# Altium schematic sheet Power_Supply.SchDoc(Power_Supply)
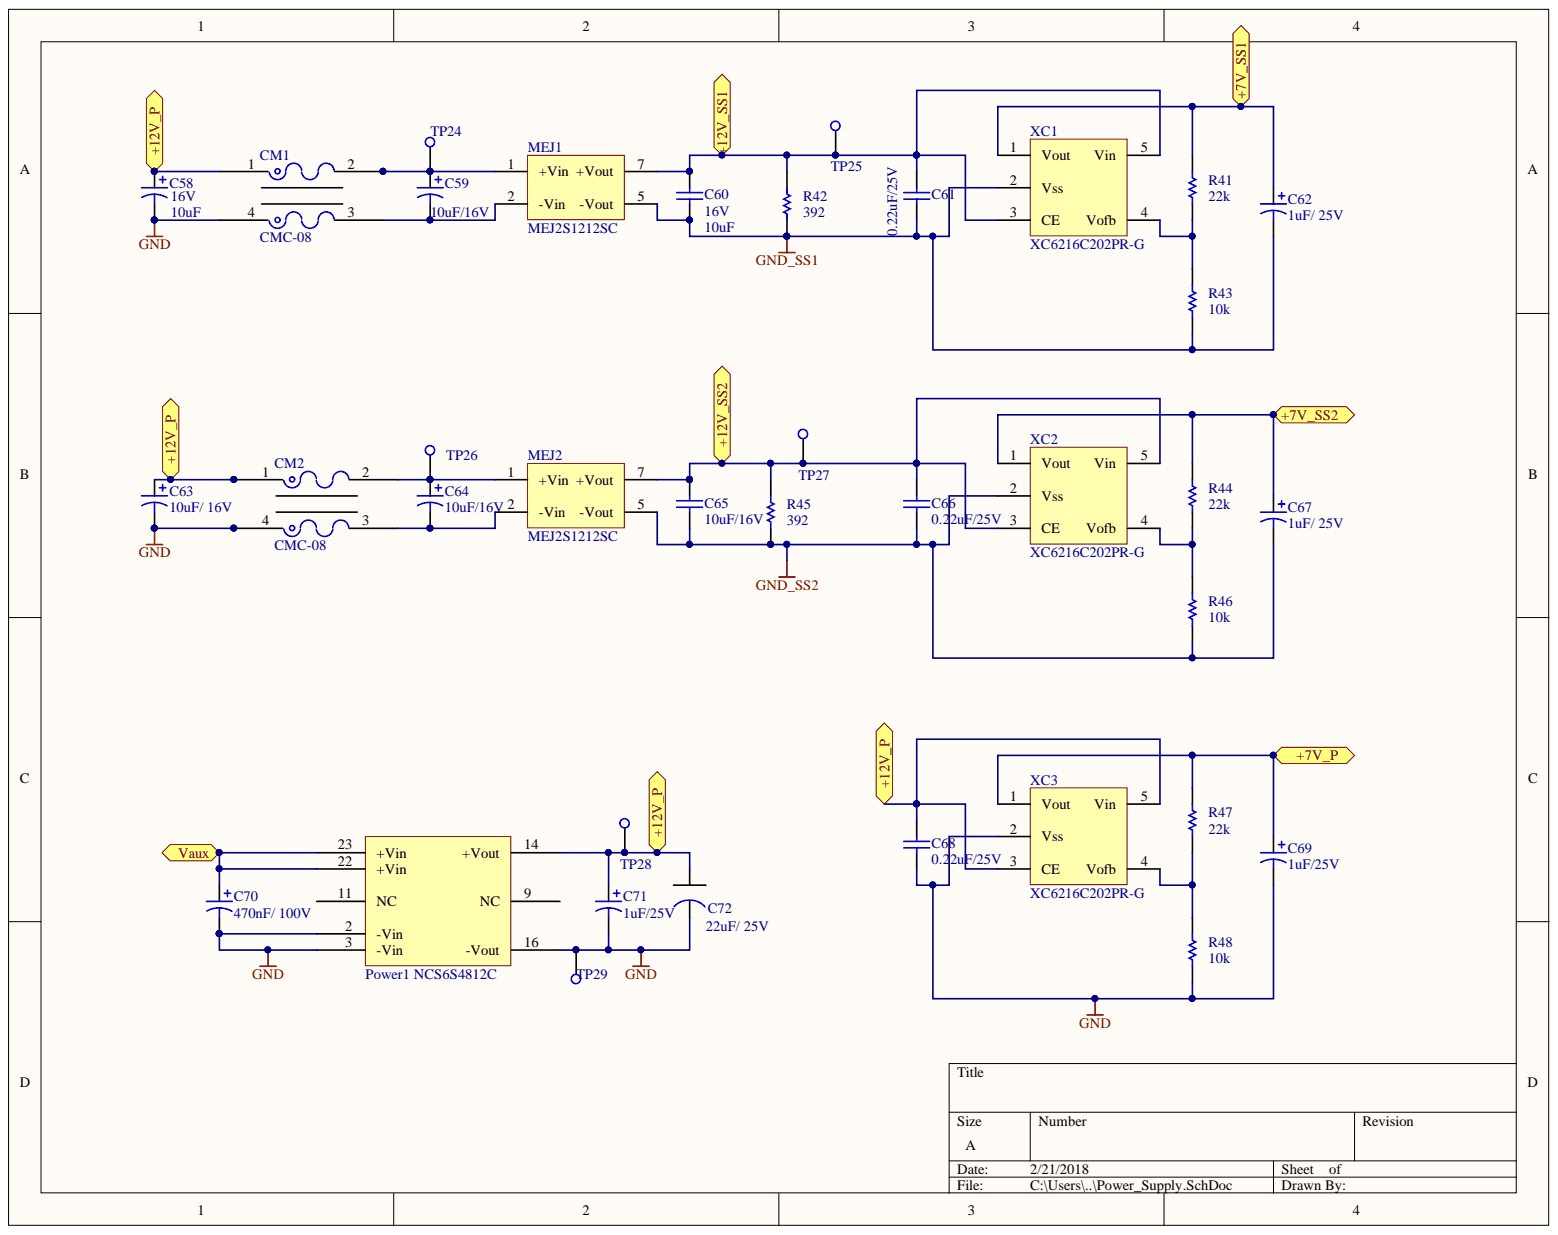
[diagram p0: Power_Supply.SchDoc]

## Parts
C58  16V  value 10uF  [1812]
C59  value 10uF/16V  [1812]  (hidden comment: Cap Pol3)
C60  16V  value 10uF  [C1206]
C61  value 0.22uF/25V  [C1206]  (hidden comment: Cap Semi)
C62  value 1uF/ 25V  [C0805]  (hidden comment: Cap Pol3)
C63  value 10uF/ 16V  [1812]  (hidden comment: Cap Pol3)
C64  value 10uF/16V  [1812]  (hidden comment: Cap Pol3)
C65  value 10uF/16V  [C1206]  (hidden comment: Cap Semi)
C66  value 0.22uF/25V  [C1206]  (hidden comment: Cap Semi)
C67  value 1uF/ 25V  [C0805]  (hidden comment: Cap Pol3)
C68  value 0.22uF/25V  [C1206]  (hidden comment: Cap Semi)
C69  value 1uF/25V  [C0805]  (hidden comment: Cap Pol3)
C70  value 470nF/ 100V  [C0805]  (hidden comment: Cap Pol3)
C71  value 1uF/25V  [C0805]  (hidden comment: Cap Pol3)
C72  22uF  [Cap_tantalum]
CM1  CMC-08  [CMC-08]
CM2  CMC-08  [CMC-08]
MEJ1  MEJ2S1212SC  [MEJ2]
MEJ2  MEJ2S1212SC  [MEJ2]
Power1  NCS6S4812C  [Power_main]
R41  res_smd  [res_smd]  (value not drawn)
R42  res_smd  [res_smd]  (value not drawn)
R43  res_smd  [res_smd]  (value not drawn)
R44  res_smd  [res_smd]  (value not drawn)
R45  res_smd  [res_smd]  (value not drawn)
R46  res_smd  [res_smd]  (value not drawn)
R47  res_smd  [res_smd]  (value not drawn)
R48  res_smd  [res_smd]  (value not drawn)
TP24  Test Point  [Test Point]  (value not drawn)
TP25  Test Point  [Test Point]  (value not drawn)
TP26  Test Point  [Test Point]  (value not drawn)
TP27  Test Point  [Test Point]  (value not drawn)
TP28  Test Point  [Test Point]  (value not drawn)
TP29  Test Point  [Test Point]  (value not drawn)
XC1  XC6216C202PR-G  [SOT-89-5]
XC2  XC6216C202PR-G  [SOT-89-5]
XC3  XC6216C202PR-G  [SOT-89-5]

## Ports
+7V_P, +7V_SS1, +7V_SS2, +12V_P, +12V_SS1, +12V_SS2, Vaux

## Nets
GND: C58.2 C63.2 C68.1 C69.2 C70.2 C71.2 C72.2 CM1.4 CM2.4 Power1.2(-Vin) Power1.3(-Vin) Power1.16(-Vout) R48.2 TP29.1 XC3.2(Vss)
GND_SS1: C60.1 C61.1 C62.2 MEJ1.5(-Vout) R42.2 R43.2 XC1.2(Vss)
GND_SS2: C65.1 C66.1 C67.2 MEJ2.5(-Vout) R45.2 R46.2 XC2.2(Vss)
+7V_P: C69.1 R47.1 XC3.1(Vout) ; port +7V_P
+7V_SS1: C62.1 R41.1 XC1.1(Vout) ; port +7V_SS1
+7V_SS2: C67.1 R44.1 XC2.1(Vout) ; port +7V_SS2
+12V_P: C58.1 C63.1 C68.2 C71.1 C72.1 CM1.1 CM2.1 Power1.14(+Vout) TP28.1 XC3.3(CE) XC3.5(Vin) ; port +12V_P
+12V_SS1: C60.2 C61.2 MEJ1.7(+Vout) R42.1 TP25.1 XC1.3(CE) XC1.5(Vin) ; port +12V_SS1
+12V_SS2: C65.2 C66.2 MEJ2.7(+Vout) R45.1 TP27.1 XC2.3(CE) XC2.5(Vin) ; port +12V_SS2
Vaux: C70.1 Power1.22(+Vin) Power1.23(+Vin) ; port Vaux
NetC59_1: C59.1 CM1.2 MEJ1.1(+Vin) TP24.1
NetC59_2: C59.2 CM1.3 MEJ1.2(-Vin)
NetC64_1: C64.1 CM2.2 MEJ2.1(+Vin) TP26.1
NetC64_2: C64.2 CM2.3 MEJ2.2(-Vin)
NetR41_2: R41.2 R43.1 XC1.4(Vofb)
NetR44_2: R44.2 R46.1 XC2.4(Vofb)
NetR47_2: R47.2 R48.1 XC3.4(Vofb)

Not connected: Power1 9(NC) 11(NC)

## Library data of the parts
C58 C59 C62-C64 C67 C69 C71 = Cap Pol3: Polarized Capacitor (Surface Mount); Simulation: CAP; Signal Integrity: Cap Pol; Published: 8-Jun-2000; PackageReference: C0805; PackageDescription: Chip Capacitor; Library Name: Miscellaneous Devices.SchLib; LatestRevisionNote: IPC-7351 Footprint Added.; LatestRevisionDate: 29-May-2009; Component Kind: Standard; Publisher: Altium Limited
C60 C61 C65 C66 C68 = Cap Semi: Capacitor (Semiconductor SIM Model); Simulation: CAP; Signal Integrity: Cap Semi; Published: 8-Jun-2000; PackageReference: C1206; PackageDescription: Chip Capacitor; Library Name: Miscellaneous Devices.SchLib; LatestRevisionNote: IPC-7351 Footprint Added.; LatestRevisionDate: 29-May-2009; Component Kind: Standard; Publisher: Altium Limited
C70 = Cap Pol3: Polarized Capacitor (Surface Mount); Value: 470nF/ 100V; Simulation: CAP; Signal Integrity: Cap Pol; Published: 8-Jun-2000; PackageReference: C0805; PackageDescription: Chip Capacitor; Library Name: Miscellaneous Devices.SchLib; LatestRevisionNote: IPC-7351 Footprint Added.; LatestRevisionDate: 29-May-2009; Component Kind: Standard; Publisher: Altium Limited
C72 = Cap_tantalum: Library Name: Schlib1.SchLib; Component Kind: Standard; : 22uF/ 25V
CM1 CM2 = CMC-08: Common mode choke; Component Kind: Standard; Library Name: lib_ankit.SchLib
MEJ1 MEJ2 = Power supply2: Component Kind: Standard; Library Name: Gan_cuk converter.SchLib
Power1 = Power_main: Library Name: Schlib1.SchLib; Component Kind: Standard
R41-R48 = res_smd: smd_res; Library Name: Schlib1.SchLib; Component Kind: Standard
  R41 R44 R47: : 22k
  R42 R45: : 392
  R43 R46 R48: : 10k
XC1-XC3 = power supply: Component Kind: Standard; Library Name: Gan_cuk converter.SchLib
TP24-TP29 = Test Point: Component Kind: Standard; Library Name: Gan_cuk converter.SchLib
